# Altium schematic sheet Battery.SchDoc(Battery)
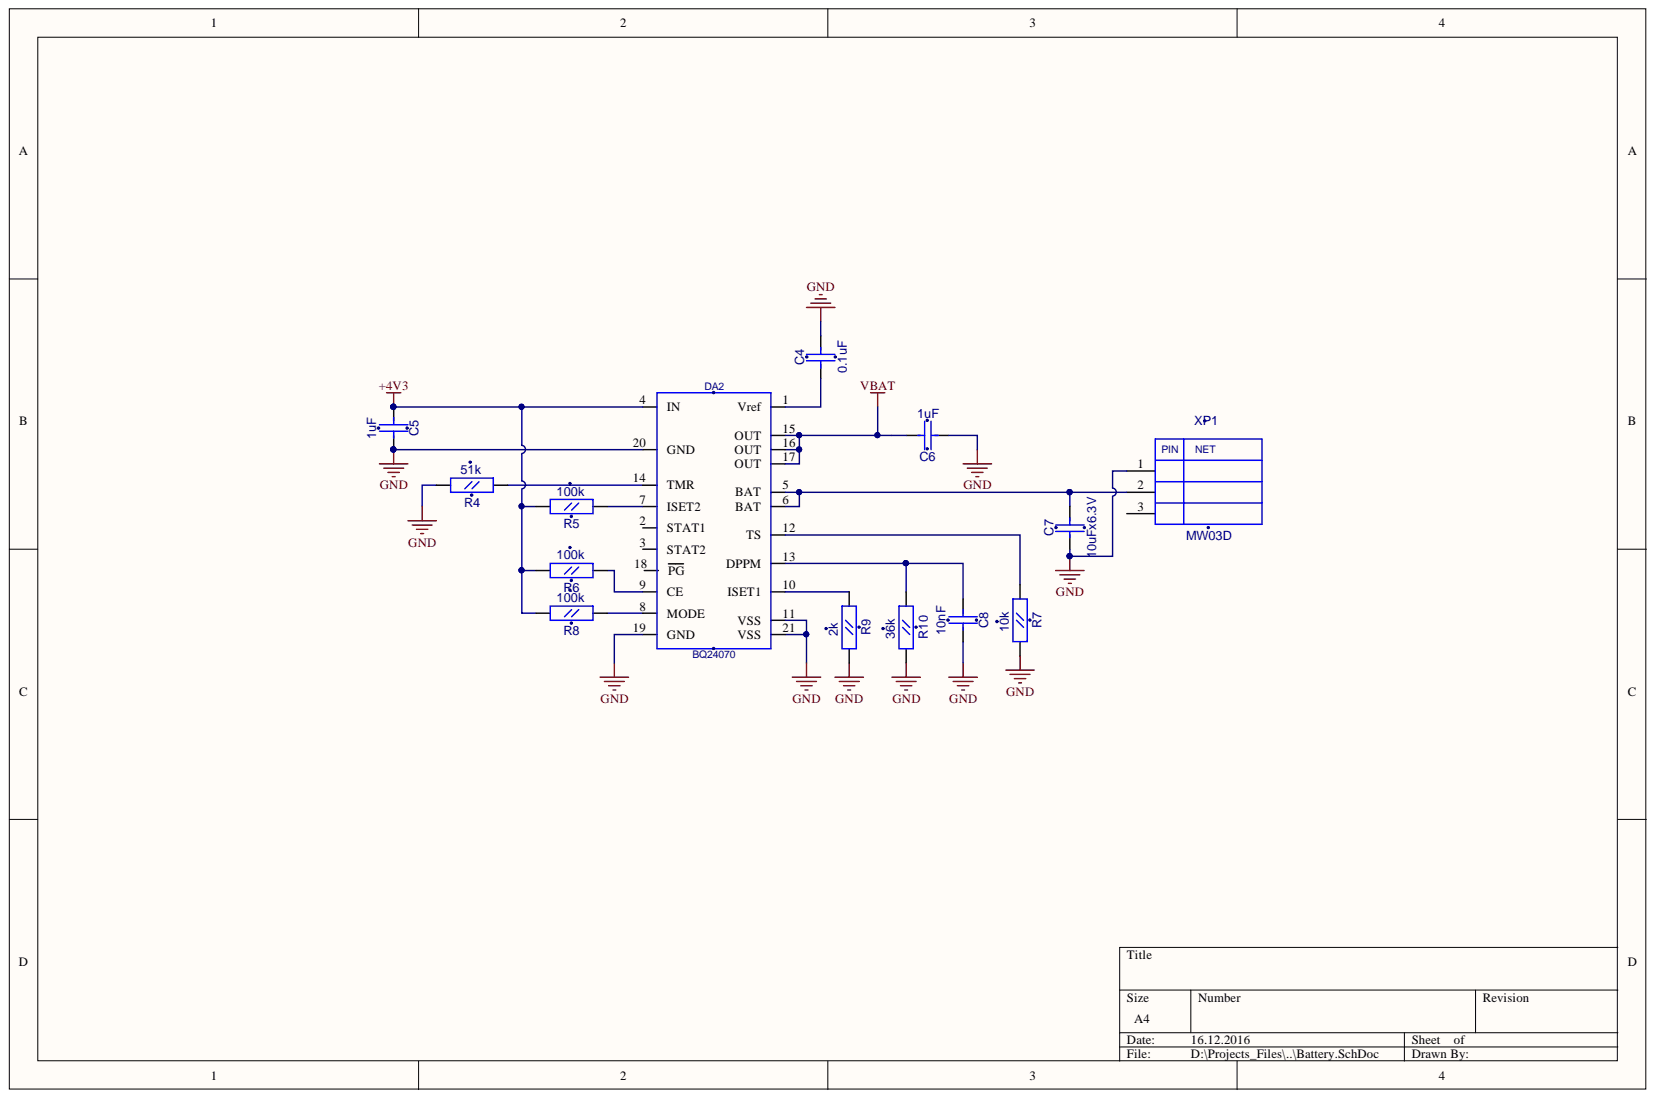
[diagram p0: Battery.SchDoc]

## Parts
C4  0.1uF  [CC0603]
C5  1uF  [CC0603]
C6  1uF  [CC0603]
C7  10uFx6.3V  [CC0805]
C8  10nF  [CC0603]
DA2  BQ24070  [P-PQFP-N20]
R4  51k  [RC0603]
R5  100k  [RC0603]
R6  100k  [RC0603]
R7  10k  [RC0603]
R8  100k  [RC0603]
R9  2k  [RC0603]
R10  36k  [RC0603]
XP1  MW03D  [MW03D]

## Nets
+4V3: C5.1 DA2.4(IN) R5.2 R6.2 R8.2
GND: C4.2 C5.2 C6.1 C7.1 C8.2 DA2.11(VSS) DA2.19(GND) DA2.20(GND) DA2.21(VSS) R4.2 R7.2 R9.2 R10.2 XP1.1
VBAT: C6.2 DA2.15(OUT) DA2.16(OUT) DA2.17(OUT)
NetC4_1: C4.1 DA2.1(Vref)
NetC7_2: C7.2 DA2.5(BAT) DA2.6(BAT) XP1.2
NetC8_1: C8.1 DA2.13(DPPM) R10.1
NetDA2_7: DA2.7(ISET2) R5.1
NetDA2_8: DA2.8(MODE) R8.1
NetDA2_9: DA2.9(CE) R6.1
NetDA2_10: DA2.10(ISET1) R9.1
NetDA2_12: DA2.12(TS) R7.1
NetDA2_14: DA2.14(TMR) R4.1

Not connected: DA2 2(STAT1) 3(STAT2) 18(PG); XP1 3

## Library data of the parts
C4-C6 C8 = CC0603: Component Kind: Standard; Description: CC0603; Library Name: gprs_modem_2.SchLib
  C4: Value: 0.1uF
  C5 C6: Value: 1uF
  C8: Value: 10nF
C7 = CC0805: Component Kind: Standard; Description: CC0805; Value: 10uFx6.3V; Library Name: gprs_modem_2.SchLib
R4-R10 = RC0603: Component Kind: Standard; Description: RC0603; Library Name: gprs_modem_2.SchLib
  R4: Value: 51k
  R5 R6 R8: Value: 100k
  R7: Value: 10k
  R9: Value: 2k
  R10: Value: 36k
DA2 = BQ24070: Component Kind: Standard; Description: BQ24070; Library Name: gprs_modem.SchLib
XP1 = MW03D: Component Kind: Standard; Description: MW03D; Library Name: gprs_modem.SchLib
